# Altium schematic sheet [05] - PERIPHERIAL.SchDoc("[05] - PERIPHERIAL")
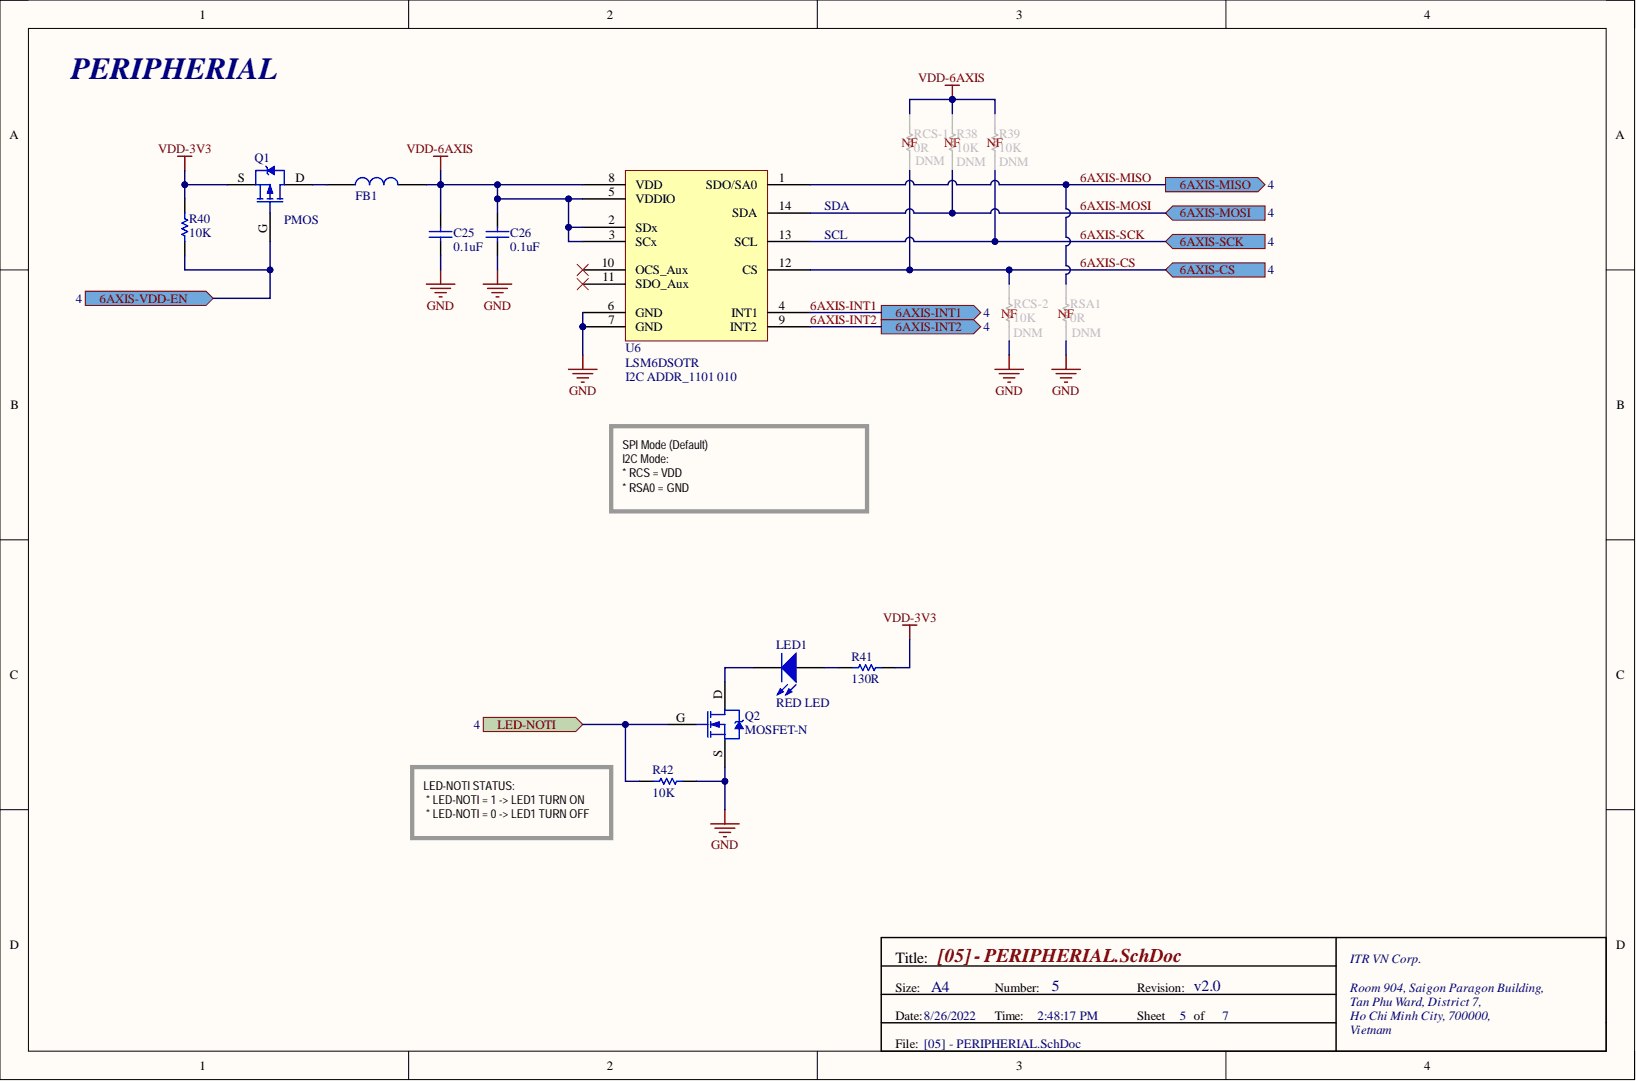
[diagram p0: [05] - PERIPHERIAL.SchDoc]

## Parts
C25  value 0.1uF  [CAPC1005X55_0402]  (hidden comment: Capacitor)
C26  value 0.1uF  [CAPC1005X55_0402]  (hidden comment: Capacitor)
FB1  Ferrit Bead  [INDC1005X50_0402]  (value not drawn)
LED1  RED LED  [LEDSC160X80X90_2_0603]
Q1  PMOS  [SOT190P250X100_3]
Q2  MOSFET-N  [SOT190P250X100_3]
R38  value 10K  [RESC1005X40_0402]  (hidden comment: Resistor)
R39  value 10K  [RESC1005X40_0402]  (hidden comment: Resistor)
R40  value 10K  [RESC1005X40_0402]  (hidden comment: Resistor)
R41  value 130R  Resistance: 130R  [RESC1005X40_0402]  (hidden comment: Resistor)
R42  value 10K  [RESC1005X40_0402]  (hidden comment: Resistor)
RCS-1  value 0R  [RESC1005X40_0402]  (hidden comment: Resistor)
RCS-2  value 10K  [RESC1005X40_0402]  (hidden comment: Resistor)
RSA1  value 0R  [RESC1005X40_0402]  (hidden comment: Resistor)
U6  LSM6DSOTR  [LSM6DSOTR]

## Ports
6AXIS-CS, 6AXIS-INT1, 6AXIS-INT2, 6AXIS-MISO, 6AXIS-MOSI, 6AXIS-SCK, 6AXIS-VDD-EN, LED-NOTI

## Nets
GND: C25.1 C26.1 Q2.S R42.2 RCS-2.2 RSA1.1 U6.6(GND) U6.7(GND)
VDD-3V3: Q1.S R40.1 R41.2
VDD-6AXIS: C25.2 C26.2 FB1.2 R38.1 R39.1 RCS-1.1 U6.2(SDx) U6.3(SCx) U6.5(VDDIO) U6.8(VDD)
6AXIS-CS: RCS-1.2 RCS-2.1 U6.12(CS) ; port 6AXIS-CS
6AXIS-INT1: U6.4(INT1) ; port 6AXIS-INT1
6AXIS-INT2: U6.9(INT2) ; port 6AXIS-INT2
6AXIS-MISO: RSA1.2 U6.1(SDO/SA0) ; port 6AXIS-MISO
6AXIS-MOSI: R38.2 U6.14(SDA) ; port 6AXIS-MOSI
6AXIS-SCK: R39.2 U6.13(SCL) ; port 6AXIS-SCK
6AXIS-VDD-EN: Q1.G R40.2 ; port 6AXIS-VDD-EN
LED-NOTI: Q2.G R42.1 ; port LED-NOTI
NetFB1_1: FB1.1 Q1.D
NetLED1_A: LED1.A R41.1
NetLED1_K: LED1.K Q2.D

Not connected: U6 10(OCS_Aux) 11(SDO_Aux)

## Library data of the parts
C25 C26 = Capacitor Semi: CAP CER 0.1UF 16V X7R 0402; Manufacturer 1: Samsung; Manufacturer Part Number 1: CL05B104KO5NNNC; Capacitance: 100nF; Material: Ceramic; Symbol status: Released; Tolerance: 10%; Case Code (Imperial): 0402; Case Code (Metric): 1005; Case/Package: 0402; Category: Ceramic Capacitors; Component Kind: Standard; ComponentLink1Description: https://datasheet.ciiva.com/26920/getdatasheetpartid-359683-26920169.pdf?src-supplier=Verical; ComponentLink1URL: https://datasheet.ciiva.com/26920/getdatasheetpartid-359683-26920169.pdf?src-supplier=Verical; ComponentLink2Description: https://datasheet.ciiva.com/29198/458718-da-01-en-vs-kondensator-0402-29198423.pdf?src-supplier=Conrad; ComponentLink2URL: https://datasheet.ciiva.com/29198/458718-da-01-en-vs-kondensator-0402-29198423.pdf?src-supplier=Conrad; ComponentLink3Description: https://datasheet.ciiva.com/26309/mlcc-catalogdownload2013-eng-26309003.pdf?src-supplier=Distrelec; ComponentLink3URL: https://datasheet.ciiva.com/26309/mlcc-catalogdownload2013-eng-26309003.pdf?src-supplier=Distrelec; ComponentLink4Description: https://datasheet.ciiva.com/16208/cl05b104kq5nnnc-16208343.pdf?src-supplier=Future+Electronics; ComponentLink4URL: https://datasheet.ciiva.com/16208/cl05b104kq5nnnc-16208343.pdf?src-supplier=Future+Electronics; Construction: Flat; Contact Plating: Tin; Depth: 0.5mm; Dielectric: X7R; Height: 0.5mm; Lead Free: Lead Free; Length: 1mm; Library Name: Miscellaneous_Devices.SchLib; Max Operating Temperature: 125°C; Min Operating Temperature: -55°C; Mount: Surface Mount; Package Quantity: 1; Packaging: Tape and Reel; Pricing 1: 1=0.10000, 10=0.02500, 50=0.01340, 100=0.01100, 500=0.00782, 1000=0.00615, 2500=0.00559, 5000=0.00514 (USD); Radiation Hardening: No; RoHS: Yes; RoHS Compliant: Yes; Series: CL; Stock 1: 25921288; Supplier 1: Digi-Key; Supplier Part Number 1: 1276-1001-1-ND; Thickness: 0.022inch; Voltage: 16V; Voltage Rating: 16V; Voltage Rating (DC): 16V; Width: 0.02inch
FB1 = Ferrit Bead: 0402 1KΩ ±25% DCR=0.65Ω 300mA; Manufacturer 1: Murata; Manufacturer Part Number 1: BLM15AG102SN1D; Core Material: Ferrite; DC Current: 200mA; DC Resistance (DCR): 650mR; DC Resistance-Max: 1R; Frequency: 100MHz; Impedance: 1kR; Material: Ferrite,Steel; Number of Circuits: 1; Shielding: Unshielded; Symbol status: Released; Case Code (Imperial): 0402; Case Code (Metric): 1005; Case/Package: 0402; Category: Ferrite Beads and Chips; Component Kind: Standard; ComponentLink10Description: https://datasheet.ciiva.com/1011/l0110s0100blm15ag-sn-1011989.pdf?src-supplier=Digi-Key; ComponentLink10URL: https://datasheet.ciiva.com/1011/l0110s0100blm15ag-sn-1011989.pdf?src-supplier=Digi-Key; ComponentLink11Description: https://datasheet.ciiva.com/3081/l0110s0100blm15ag-sn-3081597.pdf?src-supplier=Avnet; ComponentLink11URL: https://datasheet.ciiva.com/3081/l0110s0100blm15ag-sn-3081597.pdf?src-supplier=Avnet; ComponentLink12Description: https://datasheet.ciiva.com/3081/l0110s0100blm15ag-sn-3081596.pdf?src-supplier=Avnet; ComponentLink12URL: https://datasheet.ciiva.com/3081/l0110s0100blm15ag-sn-3081596.pdf?src-supplier=Avnet; ComponentLink1Description: https://datasheet.ciiva.com/11799/0900766b811b5866-11799186.pdf?src-supplier=RSComponents; ComponentLink1URL: https://datasheet.ciiva.com/11799/0900766b811b5866-11799186.pdf?src-supplier=RSComponents; ComponentLink2Description: https://datasheet.ciiva.com/18093/getdatasheetpartid-153001-18093091.pdf?src-supplier=Verical; ComponentLink2URL: https://datasheet.ciiva.com/18093/getdatasheetpartid-153001-18093091.pdf?src-supplier=Verical; ComponentLink3Description: https://datasheet.ciiva.com/41425/001171673-da-01-en-chip-drossel0402-1000r-300ma-1-0x0-5mm-41425718.pdf?src-supplier=Conrad; ComponentLink3URL: https://datasheet.ciiva.com/41425/001171673-da-01-en-chip-drossel0402-1000r-300ma-1-0x0-5mm-41425718.pdf?src-supplier=Conrad; ComponentLink4Description: https://datasheet.ciiva.com/41449/001172159-da-01-en-drossel-0402-1000r-1-0x0-5mm-10000st-41449447.pdf?src-supplier=Conrad; ComponentLink4URL: https://datasheet.ciiva.com/41449/001172159-da-01-en-drossel-0402-1000r-1-0x0-5mm-10000st-41449447.pdf?src-supplier=Conrad; ComponentLink5Description: https://datasheet.ciiva.com/1466/5144-1466738.pdf?src-supplier=Element14; ComponentLink5URL: https://datasheet.ciiva.com/1466/5144-1466738.pdf?src-supplier=Element14; ComponentLink6Description: https://datasheet.ciiva.com/1466/5144-1466739.pdf?src-supplier=Element14; ComponentLink6URL: https://datasheet.ciiva.com/1466/5144-1466739.pdf?src-supplier=Element14; ComponentLink7Description: https://datasheet.ciiva.com/14122/blm-series-eng-tds-14122895.pdf?src-supplier=Distrelec; ComponentLink7URL: https://datasheet.ciiva.com/14122/blm-series-eng-tds-14122895.pdf?src-supplier=Distrelec; ComponentLink8Description: https://datasheet.ciiva.com/1011/l0110s0100blm15ag-sn-1011988.pdf?src-supplier=Digi-Key; ComponentLink8URL: https://datasheet.ciiva.com/1011/l0110s0100blm15ag-sn-1011988.pdf?src-supplier=Digi-Key; ComponentLink9Description: https://datasheet.ciiva.com/1011/l0110s0100blm15ag-sn-1011987.pdf?src-supplier=Digi-Key; ComponentLink9URL: https://datasheet.ciiva.com/1011/l0110s0100blm15ag-sn-1011987.pdf?src-supplier=Digi-Key; Current Rating: 300mA; Depth: 0.55mm; Height: 0.5mm; Lead Free: Lead Free; Length: 1mm; Library Name: Miscellaneous_Devices.SchLib; Max Current Rating: 300mA; Max DC Current: 200mA; Max Operating Temperature: 125°C; Military Standard: Not; Min Operating Temperature: -55°C; Number of Lines: 1; Number of Pins: 2; Package Quantity: 1; Packaging: Tape and Reel; Pricing 1: 1=0.10000, 10=0.06200, 25=0.05480, 50=0.05000, 100=0.04490, 250=0.03296, 500=0.02396, 1000=0.02347, 5000=0.01598 (USD); Radiation Hardening: No; REACH SVHC: No SVHC; RoHS: Yes; RoHS Compliant: Yes; Series: BLM15AG_SN; Stock 1: 3751571; Supplier 1: Digi-Key; Supplier Part Number 1: 490-1007-1-ND; Termination: SMD/SMT; Test Frequency: 100MHz; Tolerance: 25%; Width: 0.5mm
LED1 = LED: Manufacturer 1: Harvatek; Symbol status: Released; Voltage Rating: 2.5V; Component Kind: Standard; Description: LED; Library Name: Miscellaneous_Devices.SchLib; Supplier 1: thegioiic.com; Supplier Part Number 1: https://www.thegioiic.com/led-do-0603-dan-smd-trong-suot-harvatek
Q1 = MOSFET-P: DMP3056L Series 30 V 4.3 A P-Channel Enhancement Mode Mosfet - SOT-23-3; Manufacturer 1: Diodes; Manufacturer Part Number 1: DMP3056L-7; Continuous Drain Current (ID): 4.3A; Drain to Source Voltage (Vdss): 30V; Input Capacitance: 642pF; Max Power Dissipation: 1.38W; Rds On Max: 50mR; Case/Package: TO-236-3; Category: MOSFETs; Component Kind: Standard; ComponentLink1Description: https://datasheet.ciiva.com/30023/dmp3099l-30023792.pdf?src-supplier=Avnet; ComponentLink1URL: https://datasheet.ciiva.com/30023/dmp3099l-30023792.pdf?src-supplier=Avnet; ComponentLink2Description: http://www.farnell.com/datasheets/2814426.pdf?src-supplier=Farnell; ComponentLink2URL: http://www.farnell.com/datasheets/2814426.pdf?src-supplier=Farnell; ComponentLink3Description: http://www.mouser.com/ds/2/115/DMP3056L-526286.pdf?src-supplier=Mouser; ComponentLink3URL: http://www.mouser.com/ds/2/115/DMP3056L-526286.pdf?src-supplier=Mouser; ComponentLink4Description: http://www.farnell.com/datasheets/2814426.pdf?src-supplier=Newark; ComponentLink4URL: http://www.farnell.com/datasheets/2814426.pdf?src-supplier=Newark; ComponentLink5Description: https://www.diodes.com/assets/Datasheets/DMP3056L.pdf?src-supplier=Digi-Key; ComponentLink5URL: https://www.diodes.com/assets/Datasheets/DMP3056L.pdf?src-supplier=Digi-Key; Library Name: Miscellaneous_Devices.SchLib; Max Operating Temperature: 150°C; Min Operating Temperature: -55°C; Mount: Surface Mount; Packaging: Tape and Reel; Pricing 1: 1=0.44000, 10=0.35800, 100=0.24390, 500=0.18296, 1000=0.13721 (USD); RoHS: Yes; RoHS Compliant: Yes; Stock 1: 52125; Supplier 1: Digi-Key; Supplier Part Number 1: DMP3056L-7DICT-ND
Q2 = MOSFET-N: Trans Mosfet N-ch 30V 0.35A 3-PIN SOT-23 T/r; Manufacturer 1: Diodes; Manufacturer Part Number 1: DMN63D8L-7; Continuous Drain Current (ID): 350mA; Input Capacitance: 23.2pF; Max Power Dissipation: 350mW; Rds On Max: 2.8R; Symbol status: Released; Case/Package: TO-236-3; Category: MOSFETs; Component Kind: Standard; ComponentLink1Description: http://www.mouser.com/ds/2/115/DMN63D8L-773918.pdf?src-supplier=Mouser; ComponentLink1URL: http://www.mouser.com/ds/2/115/DMN63D8L-773918.pdf?src-supplier=Mouser; ComponentLink2Description: https://www.diodes.com/assets/Datasheets/DMN63D8L.pdf?src-supplier=Digi-Key; ComponentLink2URL: https://www.diodes.com/assets/Datasheets/DMN63D8L.pdf?src-supplier=Digi-Key; Drain to Source Voltage (Vdss): 30V; Library Name: Miscellaneous_Devices.SchLib; Max Operating Temperature: 150°C; Min Operating Temperature: -55°C; Mount: Surface Mount; Packaging: Tape and Reel; Pricing 1: 1=0.26000, 10=0.21200, 100=0.11220, 500=0.07384, 1000=0.05021 (USD); RoHS: Yes; RoHS Compliant: Yes; Stock 1: 571578; Supplier 1: Digi-Key; Supplier Part Number 1: DMN63D8L-7DICT-ND
R38-R40 R42 RCS-2 = Res1: RES SMD 10K OHM 5% 1/10W 0402; Manufacturer 1: Panasonic; Manufacturer Part Number 1: ERJ-2GEJ103X; BOM Type: A; Max Power Dissipation: 100mW; Resistance: 10kR; Temperature Coefficient: 200ppm/°C; Voltage Rating: 50V; Case Code (Imperial): 0402; Case Code (Metric): 1005; Case/Package: 0402; Category: Chip SMD Resistors; Component Kind: Standard; ComponentLink1Description: https://datasheet.ciiva.com/11821/0900766b80f806dd-11821170.pdf?src-supplier=RSComponents; ComponentLink1URL: https://datasheet.ciiva.com/11821/0900766b80f806dd-11821170.pdf?src-supplier=RSComponents; ComponentLink2Description: https://datasheet.ciiva.com/27055/1935721-27055112.pdf?src-supplier=Jameco; ComponentLink2URL: https://datasheet.ciiva.com/27055/1935721-27055112.pdf?src-supplier=Jameco; ComponentLink3Description: https://datasheet.ciiva.com/17212/aoa0000ce1-17212101.pdf?src-supplier=Avnet+Europe; ComponentLink3URL: https://datasheet.ciiva.com/17212/aoa0000ce1-17212101.pdf?src-supplier=Avnet+Europe; ComponentLink4Description: https://datasheet.ciiva.com/46371/1678796-46371910.pdf?src-supplier=Element14; ComponentLink4URL: https://datasheet.ciiva.com/46371/1678796-46371910.pdf?src-supplier=Element14; ComponentLink5Description: https://datasheet.ciiva.com/24070/aoa0000ce1-37422-24070679.pdf?src-supplier=Mouser; ComponentLink5URL: https://datasheet.ciiva.com/24070/aoa0000ce1-37422-24070679.pdf?src-supplier=Mouser; ComponentLink6Description: https://datasheet.ciiva.com/43460/1678796-43460391.pdf?src-supplier=Farnell; ComponentLink6URL: https://datasheet.ciiva.com/43460/1678796-43460391.pdf?src-supplier=Farnell; ComponentLink7Description: https://datasheet.ciiva.com/16774/70260739-16774504.pdf?src-supplier=Allied+Electronics; ComponentLink7URL: https://datasheet.ciiva.com/16774/70260739-16774504.pdf?src-supplier=Allied+Electronics; Contact Plating: Tin; Depth: 0.5mm; Features: Automotive AEC-Q200; Height: 0.35mm; LatestRevisionDate: 17-Jul-2002; LatestRevisionNote: Re-released for DXP Platform.; Lead Free: Lead Free; Length: 1mm; Library Name: Miscellaneous Devices.IntLib; Max Operating Temperature: 155°C; Military Standard: Not; Min Operating Temperature: -55°C; Mount: Surface Mount; Number of Terminations: 2; Package Quantity: 1; PackageDescription: Axial Device, Thru-Hole; 2 Leads; 0.3 in Pin Spacing; PackageReference: AXIAL-0.3; Packaging: Cut Tape; Power Rating: 100mW; Pricing 1: 1=0.10000, 10=0.01900, 100=0.00770, 1000=0.00345, 2500=0.00299, 5000=0.00247 (USD); Published: 8-Jun-2000; Radiation Hardening: No; REACH SVHC: No SVHC; Resistor Type: Thick Film; RoHS: Yes; Signal Integrity: Res; Simulation: RESISTOR; Stock 1: 2048955; Supplier 1: Digi-Key; Supplier Part Number 1: P10KJCT-ND; Termination: SMD/SMT; Tolerance: 5%; Voltage Rating (DC): 50V; Width: 0.02inch; Publisher: Altium Limited
  R38 R39 RCS-2: DNM: DNM
R41 = Resistor 1: Resistor; Thick Film; Res 130 Ohms; Pwr-Rtg 0.1 W; Tol 1%; SMT; 0402; Tape & Reel; Manufacturer 1: Panasonic; Manufacturer Part Number 1: ERJ-2RKF1300X; Max Power Dissipation: 100mW; Temperature Coefficient: 100ppm/°C; Voltage Rating: 50V; Case Code (Imperial): 0402; Case Code (Metric): 1005; Case/Package: 0402; Category: Chip SMD Resistors; Component Kind: Standard; ComponentLink10Description: https://datasheet.ciiva.com/30066/aoa0000ce2-30066815.pdf?src-supplier=Onlinecomponents.com; ComponentLink10URL: https://datasheet.ciiva.com/30066/aoa0000ce2-30066815.pdf?src-supplier=Onlinecomponents.com; ComponentLink1Description: https://datasheet.ciiva.com/34303/1987118-34303554.pdf?src-supplier=Jameco; ComponentLink1URL: https://datasheet.ciiva.com/34303/1987118-34303554.pdf?src-supplier=Jameco; ComponentLink2Description: https://datasheet.ciiva.com/11821/0900766b80f80784-11821190.pdf?src-supplier=RSComponents; ComponentLink2URL: https://datasheet.ciiva.com/11821/0900766b80f80784-11821190.pdf?src-supplier=RSComponents; ComponentLink3Description: https://datasheet.ciiva.com/43460/426256-43460281.pdf?src-supplier=Farnell; ComponentLink3URL: https://datasheet.ciiva.com/43460/426256-43460281.pdf?src-supplier=Farnell; ComponentLink4Description: https://datasheet.ciiva.com/46388/426256-46388453.pdf?src-supplier=Element14; ComponentLink4URL: https://datasheet.ciiva.com/46388/426256-46388453.pdf?src-supplier=Element14; ComponentLink5Description: https://datasheet.ciiva.com/5915/426256-5915275.pdf?src-supplier=Farnell; ComponentLink5URL: https://datasheet.ciiva.com/5915/426256-5915275.pdf?src-supplier=Farnell; ComponentLink6Description: https://datasheet.ciiva.com/28665/1678782-28665375.pdf?src-supplier=Element14; ComponentLink6URL: https://datasheet.ciiva.com/28665/1678782-28665375.pdf?src-supplier=Element14; ComponentLink7Description: https://datasheet.ciiva.com/24025/aoa0000ce2-24025131.pdf?src-supplier=Mouser; ComponentLink7URL: https://datasheet.ciiva.com/24025/aoa0000ce2-24025131.pdf?src-supplier=Mouser; ComponentLink8Description: https://datasheet.ciiva.com/16776/70260748-16776109.pdf?src-supplier=Allied+Electronics; ComponentLink8URL: https://datasheet.ciiva.com/16776/70260748-16776109.pdf?src-supplier=Allied+Electronics; ComponentLink9Description: https://datasheet.ciiva.com/15825/aoa0000ce2-15825609.pdf?src-supplier=Onlinecomponents.com; ComponentLink9URL: https://datasheet.ciiva.com/15825/aoa0000ce2-15825609.pdf?src-supplier=Onlinecomponents.com; Construction: Rectangular; Contact Plating: Tin; Depth: 0.5mm; Features: Automotive AEC-Q200; Height: 0.35mm; Lead Free: Lead Free; Length: 1mm; Library Name: Miscellaneous_Devices.SchLib; Max Operating Temperature: 155°C; Military Standard: Not; Min Operating Temperature: -55°C; Mount: Surface Mount; Number of Terminations: 2; Package Quantity: 1; Packaging: Tape and Reel; Power Rating: 100mW; Pricing 1: 1=0.10000, 10=0.03500, 100=0.01420, 1000=0.00636, 2500=0.00552, 5000=0.00456 (USD); REACH SVHC: No SVHC; Resistance Tolerance: 1%; Resistor Type: Thick Film; RoHS: Yes; RoHS Compliant: Yes; Series: ERJ; Stock 1: 53365; Supplier 1: Digi-Key; Supplier Part Number 1: P130LCT-ND; Technology: THICK FILM; Termination: SMD/SMT; Tolerance: 1%; Voltage Rating (DC): 50V; Width: 0.02inch
RCS-1 RSA1 = Resistor: SMD Chip Resistor, Jumper, 0 ohm, 100 mW, 0402 [1005 Metric], Thick Film, General Purpose; Manufacturer 1: Panasonic; Manufacturer Part Number 1: ERJ-2GE0R00X; Max Power Dissipation: 100mW; Resistance: 0mR; Temperature Coefficient: 600ppm/°C; Tolerance: 5%; Voltage Rating: 50V; Case Code (Imperial): 0402; Case Code (Metric): 1005; Case/Package: 0402; Category: Chip SMD Resistors; Component Kind: Standard; ComponentLink1Description: https://datasheet.ciiva.com/11821/0900766b80f806dd-11821176.pdf?src-supplier=RSComponents; ComponentLink1URL: https://datasheet.ciiva.com/11821/0900766b80f806dd-11821176.pdf?src-supplier=RSComponents; ComponentLink2Description: https://datasheet.ciiva.com/43460/1678796-43460347.pdf?src-supplier=Farnell; ComponentLink2URL: https://datasheet.ciiva.com/43460/1678796-43460347.pdf?src-supplier=Farnell; ComponentLink3Description: https://datasheet.ciiva.com/46389/1678796-46389518.pdf?src-supplier=Element14; ComponentLink3URL: https://datasheet.ciiva.com/46389/1678796-46389518.pdf?src-supplier=Element14; ComponentLink4Description: https://datasheet.ciiva.com/5959/1678796-5959692.pdf?src-supplier=Farnell; ComponentLink4URL: https://datasheet.ciiva.com/5959/1678796-5959692.pdf?src-supplier=Farnell; ComponentLink5Description: https://datasheet.ciiva.com/28695/1678796-28695758.pdf?src-supplier=Element14; ComponentLink5URL: https://datasheet.ciiva.com/28695/1678796-28695758.pdf?src-supplier=Element14; ComponentLink6Description: https://datasheet.ciiva.com/16783/70260739-16783734.pdf?src-supplier=Allied+Electronics; ComponentLink6URL: https://datasheet.ciiva.com/16783/70260739-16783734.pdf?src-supplier=Allied+Electronics; ComponentLink7Description: https://datasheet.ciiva.com/16778/70260739-16778335.pdf?src-supplier=Allied+Electronics; ComponentLink7URL: https://datasheet.ciiva.com/16778/70260739-16778335.pdf?src-supplier=Allied+Electronics; ComponentLink8Description: https://datasheet.ciiva.com/48531/aoa0000ce1-48531077.pdf?src-supplier=Avnet; ComponentLink8URL: https://datasheet.ciiva.com/48531/aoa0000ce1-48531077.pdf?src-supplier=Avnet; ComponentLink9Description: https://datasheet.ciiva.com/30097/4aoa0000ce1-30097116.pdf?src-supplier=Onlinecomponents.com; ComponentLink9URL: https://datasheet.ciiva.com/30097/4aoa0000ce1-30097116.pdf?src-supplier=Onlinecomponents.com; Construction: Rectangular; Contact Plating: Tin; Depth: 0.5mm; DNM: DNM; Features: Automotive AEC-Q200; Height: 0.35mm; Lead Free: Lead Free; Length: 1mm; Library Name: Miscellaneous_Devices.SchLib; Max Operating Temperature: 155°C; Military Standard: Not; Min Operating Temperature: -55°C; Mount: Surface Mount; Number of Terminations: 2; Package Quantity: 1; Packaging: Tape and Reel; Power Rating: 100mW; Pricing 1: 1=0.10000, 10=0.02700, 25=0.01960, 50=0.01500, 100=0.01100, 250=0.00840, 500=0.00674, 1000=0.00496, 5000=0.00355 (USD); Radiation Hardening: No; REACH SVHC: No SVHC; Resistor Type: Thick Film; RoHS: Yes; RoHS Compliant: Yes; Series: ERJ; Size Code: 0402; Stock 1: 12230000; Supplier 1: Digi-Key; Supplier Part Number 1: P0.0JCT-ND; Technology: THICK FILM; Termination: SMD/SMT; Voltage Rating (DC): 50V; Width: 0.02inch
U6 = LSM6DSOTR: Accelerometer and Gyroscope Digital Output 1.8V 14-Pin VFLGA T/R; Manufacturer 1: STMicroelectronics; Output Type: SPI,I2C; Sensor Type: Accelerometer,Gyroscope,3 Axis; Case/Package: LGA; Category: Inertial Measurement Units (IMUs); Component Kind: Standard; ComponentLink1Description: http://www.farnell.com/datasheets/2710914.pdf?src-supplier=Farnell; ComponentLink1URL: http://www.farnell.com/datasheets/2710914.pdf?src-supplier=Farnell; ComponentLink2Description: https://www.st.com/resource/en/datasheet/lsm6dso.pdf?src-supplier=Digi-Key; ComponentLink2URL: https://www.st.com/resource/en/datasheet/lsm6dso.pdf?src-supplier=Digi-Key; ComponentLink3Description: http://www.farnell.com/datasheets/2710914.pdf?src-supplier=Newark; ComponentLink3URL: http://www.farnell.com/datasheets/2710914.pdf?src-supplier=Newark; Manufacturer Part Number 1: LSM6DSOTR; Max Operating Temperature: 85°C; Min Operating Temperature: -40°C; Mount: Surface Mount; Packaging: Cut Tape; RoHS: Yes; RoHS Compliant: Yes; Supplier 1: Digi-Key; Supplier Part Number 1: 497-18181-1-ND; Library Name: TENA_V2.0.SchLib
